# Altium schematic sheet main.SchDoc
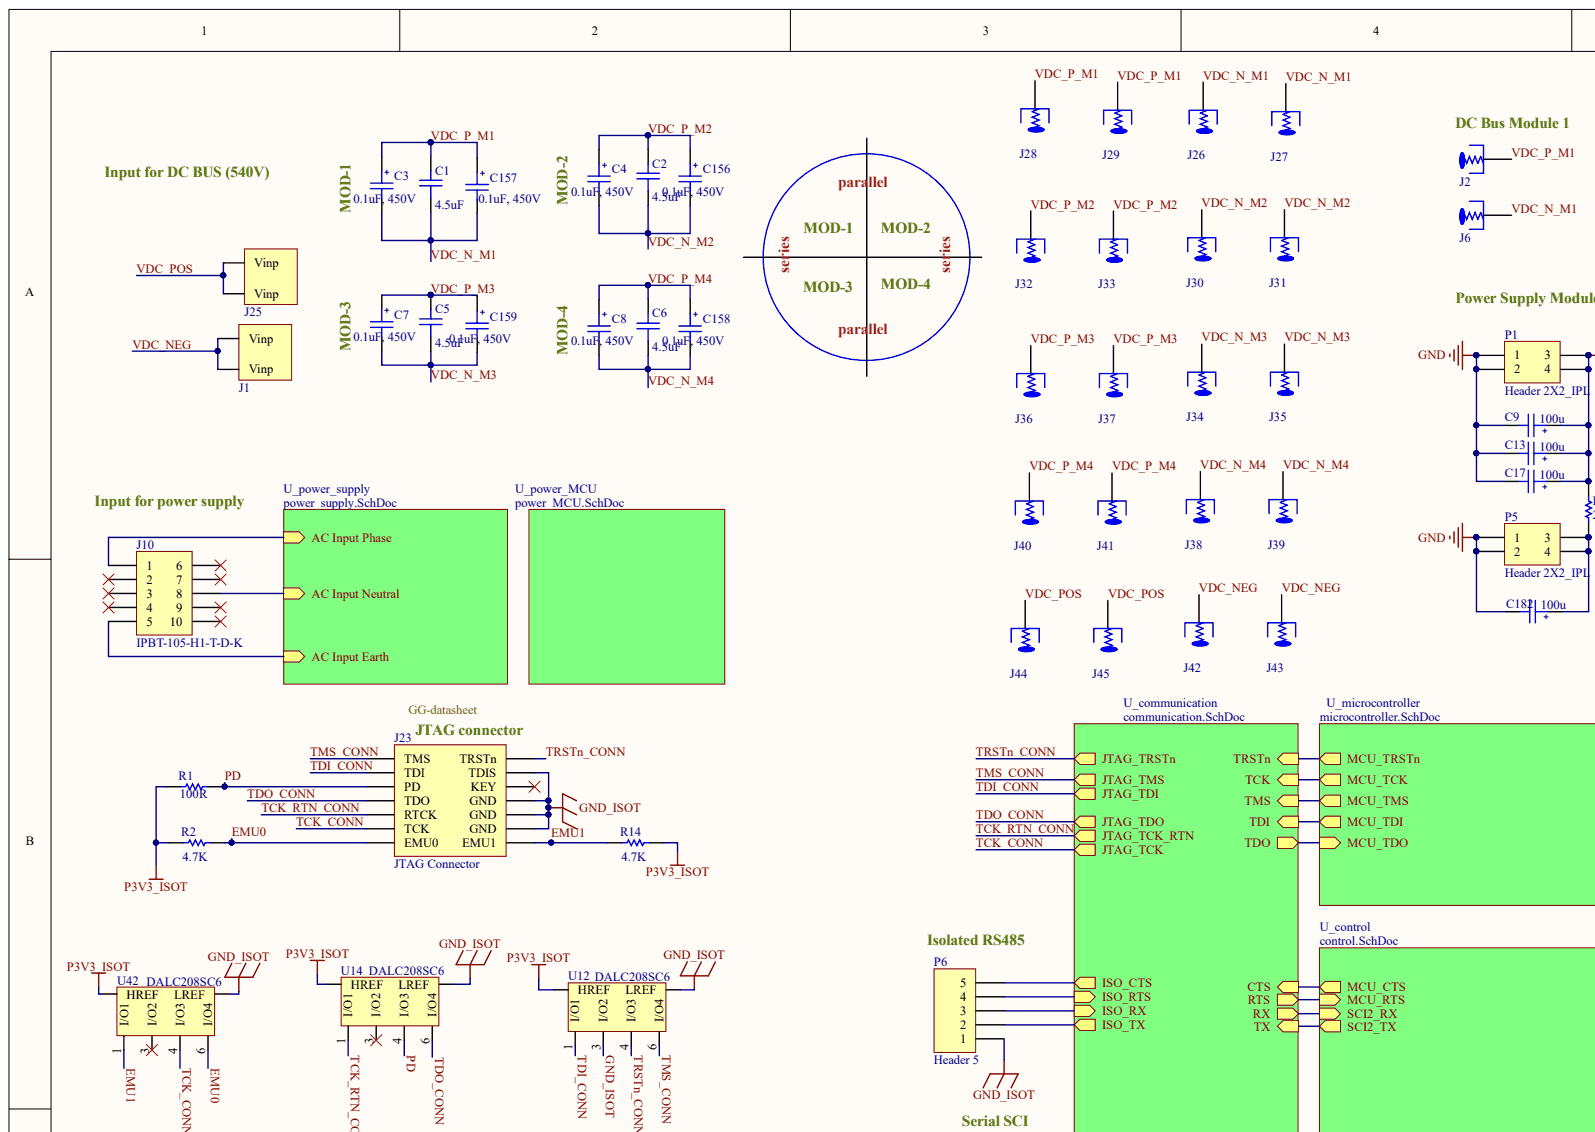
[diagram p0: main.SchDoc, top left]
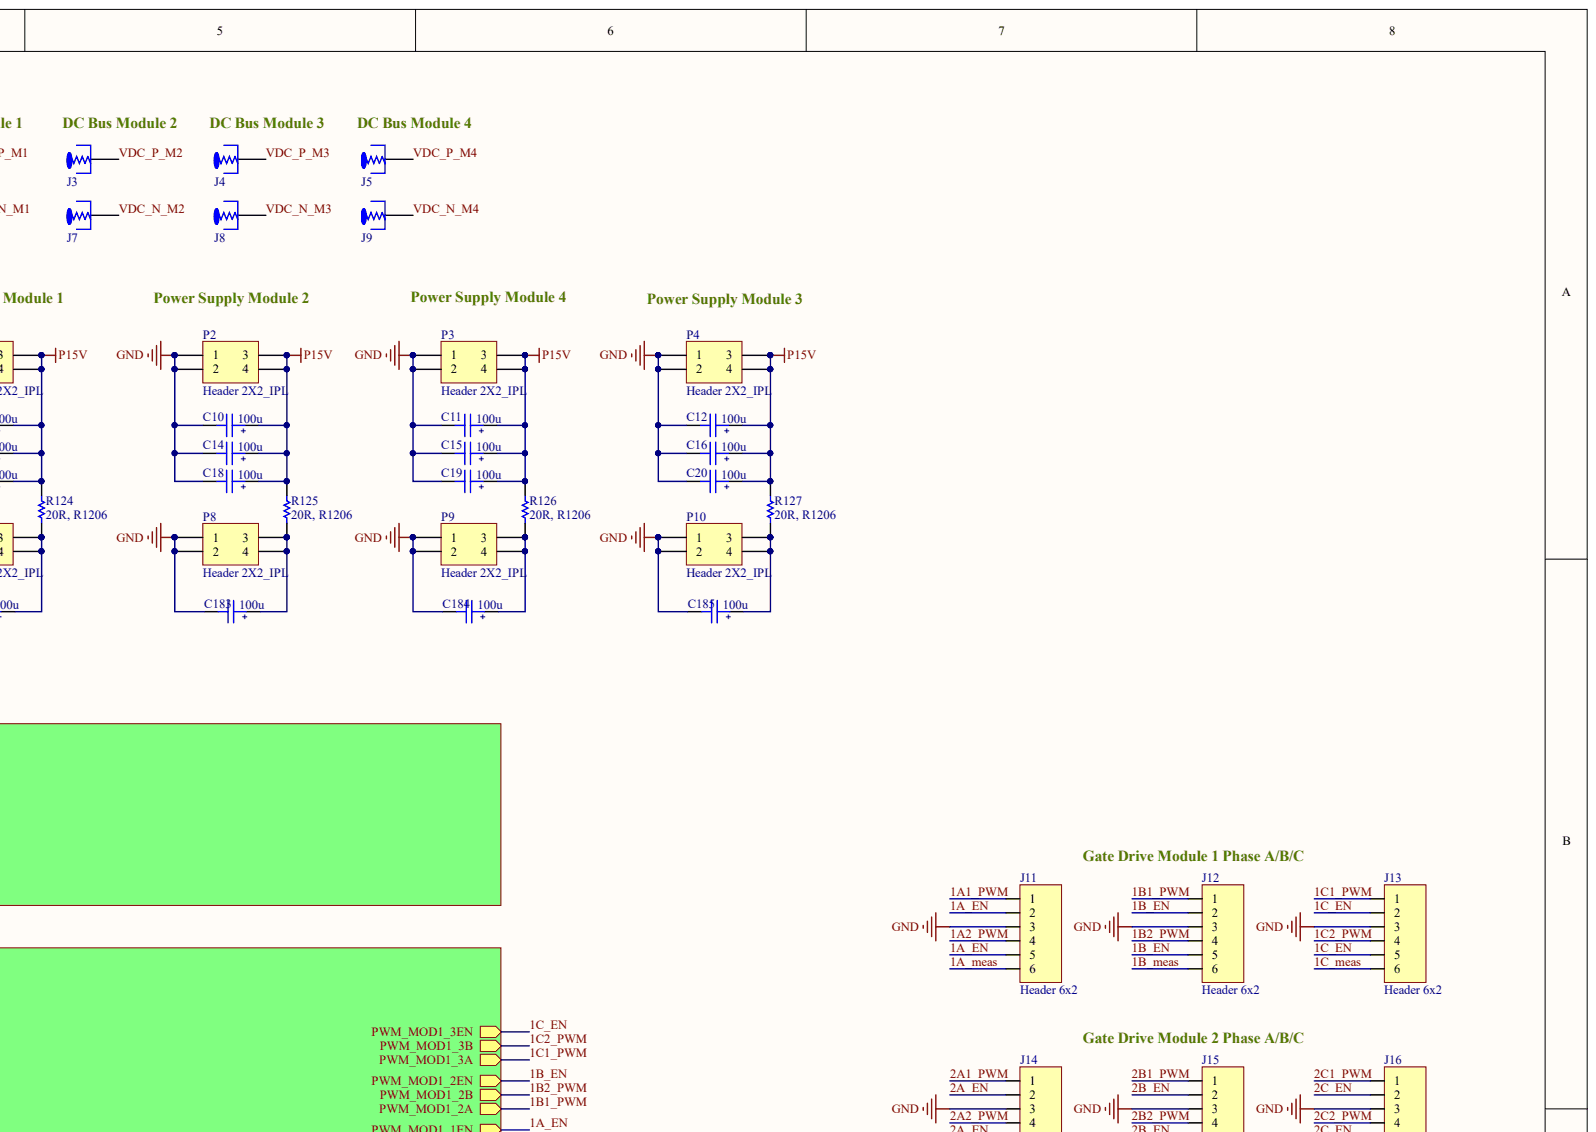
[diagram p1: main.SchDoc, top right]
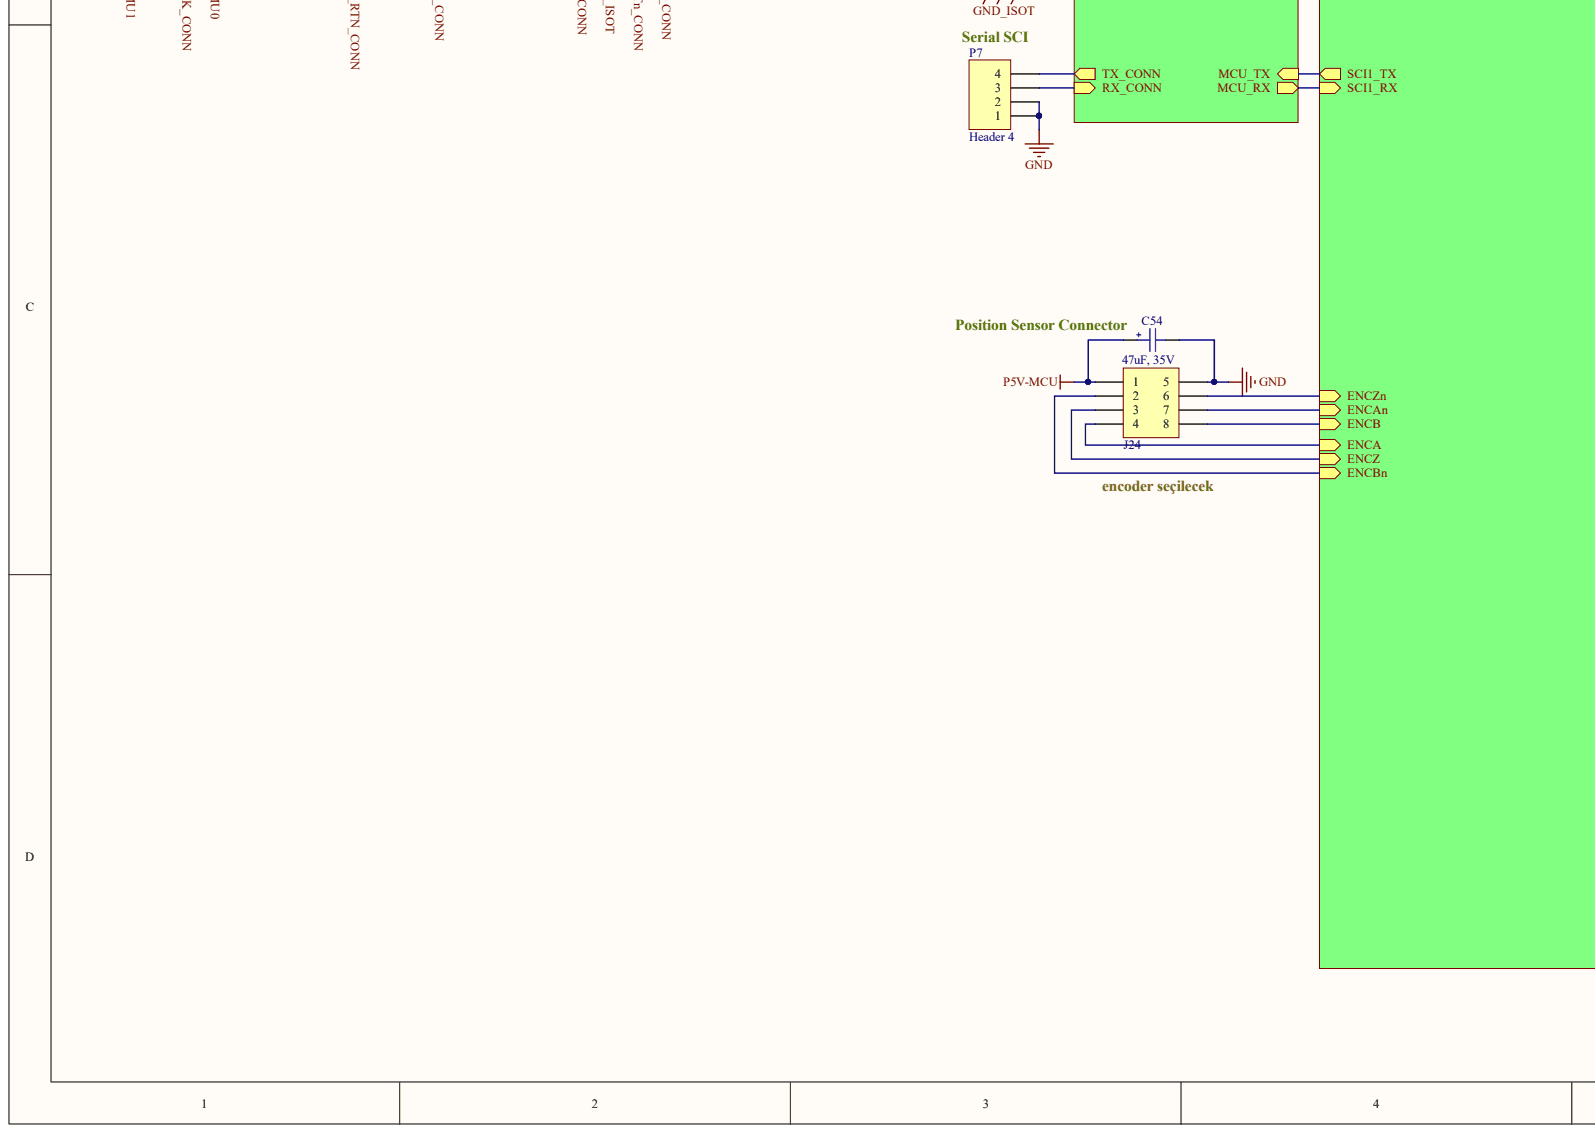
[diagram p2: main.SchDoc, bottom left]
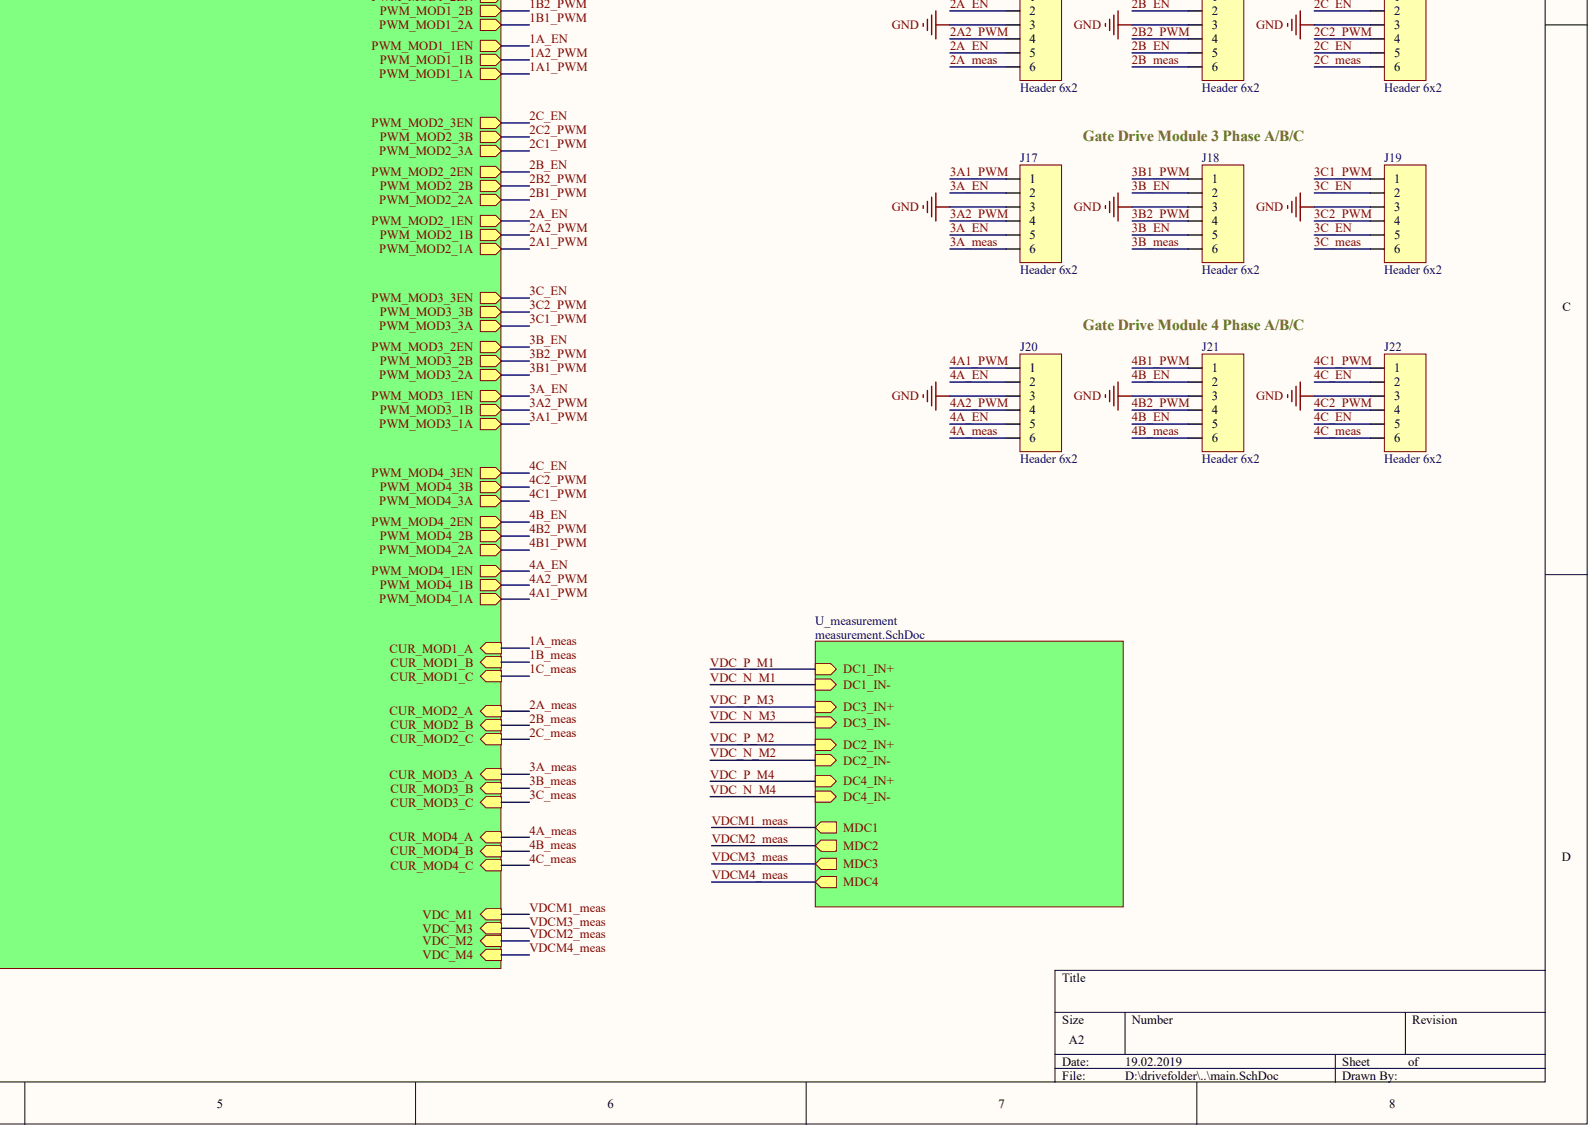
[diagram p3: main.SchDoc, bottom right]

## Parts
C1  4.5uF  [Film_Cap_11*31.5*21]
C2  4.5uF  [Film_Cap_11*31.5*21]
C3  0.1uF, 450V  [1206 Package]
C4  0.1uF, 450V  [1206 Package]
C5  4.5uF  [Film_Cap_11*31.5*21]
C6  4.5uF  [Film_Cap_11*31.5*21]
C7  0.1uF, 450V  [1206 Package]
C8  0.1uF, 450V  [1206 Package]
C9  100u  [CAPPR6.3x]
C10  100u  [CAPPR6.3x]
C11  100u  [CAPPR6.3x]
C12  100u  [CAPPR6.3x]
C13  100u  [CAPPR6.3x]
C14  100u  [CAPPR6.3x]
C15  100u  [CAPPR6.3x]
C16  100u  [CAPPR6.3x]
C17  100u  [CAPPR6.3x]
C18  100u  [CAPPR6.3x]
C19  100u  [CAPPR6.3x]
C20  100u  [CAPPR6.3x]
C54  47uF, 35V  [CAP_CAN_D5]
C156  0.1uF, 450V  [1206 Package]
C157  0.1uF, 450V  [1206 Package]
C158  0.1uF, 450V  [1206 Package]
C159  0.1uF, 450V  [1206 Package]
C182  100u  [CAPPR6.3x]
C183  100u  [CAPPR6.3x]
C184  100u  [CAPPR6.3x]
C185  100u  [CAPPR6.3x]
J1  Terminal_Block_30Deg  [Term_Block_30DEG]  (value not drawn)
J2  Terminal_Screw  [Terminal_Screw]  (value not drawn)
J3  Terminal_Screw  [Terminal_Screw]  (value not drawn)
J4  Terminal_Screw  [Terminal_Screw]  (value not drawn)
J5  Terminal_Screw  [Terminal_Screw]  (value not drawn)
J6  Terminal_Screw  [Terminal_Screw]  (value not drawn)
J7  Terminal_Screw  [Terminal_Screw]  (value not drawn)
J8  Terminal_Screw  [Terminal_Screw]  (value not drawn)
J9  Terminal_Screw  [Terminal_Screw]  (value not drawn)
J10  IPBT-105-H1-T-D-K  [IPBT-105-H1-T-D-K]
J11  Header 6x2  [HDR6x2_IPL]
J12  Header 6x2  [HDR6x2_IPL]
J13  Header 6x2  [HDR6x2_IPL]
J14  Header 6x2  [HDR6x2_IPL]
J15  Header 6x2  [HDR6x2_IPL]
J16  Header 6x2  [HDR6x2_IPL]
J17  Header 6x2  [HDR6x2_IPL]
J18  Header 6x2  [HDR6x2_IPL]
J19  Header 6x2  [HDR6x2_IPL]
J20  Header 6x2  [HDR6x2_IPL]
J21  Header 6x2  [HDR6x2_IPL]
J22  Header 6x2  [HDR6x2_IPL]
J23  JTAG Connector  [5103309-2]
J24  Header 4x2  [HDR4X2_IPL]  (value not drawn)
J25  Terminal_Block_30Deg  [Term_Block_30DEG]  (value not drawn)
J26  BUSH, THR OPENM4, THR 2.5MM  [BUSH, THR OPENM4, THR 2.5MM]  (value not drawn)
J27  BUSH, THR OPENM4, THR 2.5MM  [BUSH, THR OPENM4, THR 2.5MM]  (value not drawn)
J28  BUSH, THR OPENM4, THR 2.5MM  [BUSH, THR OPENM4, THR 2.5MM]  (value not drawn)
J29  BUSH, THR OPENM4, THR 2.5MM  [BUSH, THR OPENM4, THR 2.5MM]  (value not drawn)
J30  BUSH, THR OPENM4, THR 2.5MM  [BUSH, THR OPENM4, THR 2.5MM]  (value not drawn)
J31  BUSH, THR OPENM4, THR 2.5MM  [BUSH, THR OPENM4, THR 2.5MM]  (value not drawn)
J32  BUSH, THR OPENM4, THR 2.5MM  [BUSH, THR OPENM4, THR 2.5MM]  (value not drawn)
J33  BUSH, THR OPENM4, THR 2.5MM  [BUSH, THR OPENM4, THR 2.5MM]  (value not drawn)
J34  BUSH, THR OPENM4, THR 2.5MM  [BUSH, THR OPENM4, THR 2.5MM]  (value not drawn)
J35  BUSH, THR OPENM4, THR 2.5MM  [BUSH, THR OPENM4, THR 2.5MM]  (value not drawn)
J36  BUSH, THR OPENM4, THR 2.5MM  [BUSH, THR OPENM4, THR 2.5MM]  (value not drawn)
J37  BUSH, THR OPENM4, THR 2.5MM  [BUSH, THR OPENM4, THR 2.5MM]  (value not drawn)
J38  BUSH, THR OPENM4, THR 2.5MM  [BUSH, THR OPENM4, THR 2.5MM]  (value not drawn)
J39  BUSH, THR OPENM4, THR 2.5MM  [BUSH, THR OPENM4, THR 2.5MM]  (value not drawn)
J40  BUSH, THR OPENM4, THR 2.5MM  [BUSH, THR OPENM4, THR 2.5MM]  (value not drawn)
J41  BUSH, THR OPENM4, THR 2.5MM  [BUSH, THR OPENM4, THR 2.5MM]  (value not drawn)
J42  BUSH, THR OPENM4, THR 2.5MM  [BUSH, THR OPENM4, THR 2.5MM]  (value not drawn)
J43  BUSH, THR OPENM4, THR 2.5MM  [BUSH, THR OPENM4, THR 2.5MM]  (value not drawn)
J44  BUSH, THR OPENM4, THR 2.5MM  [BUSH, THR OPENM4, THR 2.5MM]  (value not drawn)
J45  BUSH, THR OPENM4, THR 2.5MM  [BUSH, THR OPENM4, THR 2.5MM]  (value not drawn)
P1  Header 2X2_IPL  [HDR2X2_IPL]
P2  Header 2X2_IPL  [HDR2X2_IPL]
P3  Header 2X2_IPL  [HDR2X2_IPL]
P4  Header 2X2_IPL  [HDR2X2_IPL]
P5  Header 2X2_IPL  [HDR2X2_IPL]
P6  Header 5  [HDR1X5]
P7  Header 4  [HDR1X4]
P8  Header 2X2_IPL  [HDR2X2_IPL]
P9  Header 2X2_IPL  [HDR2X2_IPL]
P10  Header 2X2_IPL  [HDR2X2_IPL]
R1  100R  [CAP0603]
R2  4.7K  [0603 (1608)]
R14  4.7K  [0603 (1608)]
R124  20R, R1206  [R1206]
R125  20R, R1206  [R1206]
R126  20R, R1206  [R1206]
R127  20R, R1206  [R1206]
U12  DALC208SC6  [SOT23 - 6 lead]
U14  DALC208SC6  [SOT23 - 6 lead]
U42  DALC208SC6  [SOT23 - 6 lead]

## Nets
GND: C9.2 C10.2 C11.2 C12.2 C13.2 C14.2 C15.2 C16.2 C17.2 C18.2 C19.2 C20.2 C54.2 C182.2 C183.2 C184.2 C185.2 J11.3 J12.3 J13.3 J14.3 J15.3 J16.3 J17.3 J18.3 J19.3 J20.3 J21.3 J22.3 J24.5 P1.1 P1.2 P2.1 P2.2 P3.1 P3.2 P4.1 P4.2 P5.1 P5.2 P7.1 P7.2 P8.1 P8.2 P9.1 P9.2 P10.1 P10.2
P3V3_ISOT: R1.1 R2.1 R14.2 U12.2(HREF) U14.2(HREF) U42.2(HREF)
P5V-MCU: C54.1 J24.1
P15V: C9.1 C10.1 C11.1 C12.1 C13.1 C14.1 C15.1 C16.1 C17.1 C18.1 C19.1 C20.1 P1.3 P1.4 P2.3 P2.4 P3.3 P3.4 P4.3 P4.4 R124.2 R125.2 R126.2 R127.2
1A1_PWM: J11.1
1A2_PWM: J11.4
1A_EN: J11.2 J11.5
1A_MEAS: J11.6
1B1_PWM: J12.1
1B2_PWM: J12.4
1B_EN: J12.2 J12.5
1B_MEAS: J12.6
1C1_PWM: J13.1
1C2_PWM: J13.4
1C_EN: J13.2 J13.5
1C_MEAS: J13.6
2A1_PWM: J14.1
2A2_PWM: J14.4
2A_EN: J14.2 J14.5
2A_MEAS: J14.6
2B1_PWM: J15.1
2B2_PWM: J15.4
2B_EN: J15.2 J15.5
2B_MEAS: J15.6
2C1_PWM: J16.1
2C2_PWM: J16.4
2C_EN: J16.2 J16.5
2C_MEAS: J16.6
3A1_PWM: J17.1
3A2_PWM: J17.4
3A_EN: J17.2 J17.5
3A_MEAS: J17.6
3B1_PWM: J18.1
3B2_PWM: J18.4
3B_EN: J18.2 J18.5
3B_MEAS: J18.6
3C1_PWM: J19.1
3C2_PWM: J19.4
3C_EN: J19.2 J19.5
3C_MEAS: J19.6
4A1_PWM: J20.1
4A2_PWM: J20.4
4A_EN: J20.2 J20.5
4A_MEAS: J20.6
4B1_PWM: J21.1
4B2_PWM: J21.4
4B_EN: J21.2 J21.5
4B_MEAS: J21.6
4C1_PWM: J22.1
4C2_PWM: J22.4
4C_EN: J22.2 J22.5
4C_MEAS: J22.6
EMU0: J23.13(EMU0) R2.2 U42.6(I/O4)
EMU1: J23.14(EMU1) R14.1 U42.1(I/O1)
GND_ISOT: J23.4(TDIS) J23.8(GND) J23.10(GND) J23.12(GND) P6.1 U12.3(I/O2) U12.5(LREF) U14.5(LREF) U42.5(LREF)
PD: J23.5(PD) R1.2 U14.4(I/O3)
TCK_CONN: J23.11(TCK) U42.4(I/O3)
TCK_RTN_CONN: J23.9(RTCK) U14.1(I/O1)
TDI_CONN: J23.3(TDI) U12.1(I/O1)
TDO_CONN: J23.7(TDO) U14.6(I/O4)
TMS_CONN: J23.1(TMS) U12.6(I/O4)
TRSTN_CONN: J23.2(TRSTn) U12.4(I/O3)
VDC_N_M1: C1.1 C3.2 C157.2 J6.1 J26.1 J27.1
VDC_N_M2: C2.1 C4.2 C156.2 J7.1 J30.1 J31.1
VDC_N_M3: C5.1 C7.2 C159.2 J8.1 J34.1 J35.1
VDC_N_M4: C6.1 C8.2 C158.2 J9.1 J38.1 J39.1
VDC_NEG: J1.1 J42.1 J43.1
VDC_P_M1: C1.2 C3.1 C157.1 J2.1 J28.1 J29.1
VDC_P_M2: C2.2 C4.1 C156.1 J3.1 J32.1 J33.1
VDC_P_M3: C5.2 C7.1 C159.1 J4.1 J36.1 J37.1
VDC_P_M4: C6.2 C8.1 C158.1 J5.1 J40.1 J41.1
VDC_POS: J25.1 J44.1 J45.1
NetC182_1: C182.1 P5.3 P5.4 R124.1
NetC183_1: C183.1 P8.3 P8.4 R125.1
NetC184_1: C184.1 P9.3 P9.4 R126.1
NetC185_1: C185.1 P10.3 P10.4 R127.1
NetJ10_1: J10.1
NetJ10_2: J10.2
NetJ10_3: J10.3
NetJ10_4: J10.4
NetJ10_5: J10.5
NetJ10_6: J10.6
NetJ10_7: J10.7
NetJ10_8: J10.8
NetJ10_9: J10.9
NetJ10_10: J10.10
NetJ23_6: J23.6(KEY)
NetJ24_2: J24.2
NetJ24_3: J24.3
NetJ24_4: J24.4
NetJ24_6: J24.6
NetJ24_7: J24.7
NetJ24_8: J24.8
NetP6_2: P6.2
NetP6_3: P6.3
NetP6_4: P6.4
NetP6_5: P6.5
NetP7_3: P7.3
NetP7_4: P7.4
NetU14_3: U14.3(I/O2)
NetU42_3: U42.3(I/O2)
CTS:
MCU_RX:
MCU_TX:
RTS:
RX:
TCK:
TDI:
TDO:
TMS:
TRSTN:
TX:
VDCM1_MEAS:
VDCM2_MEAS:
VDCM3_MEAS:
VDCM4_MEAS:

## Library data of the parts
C1 C2 C5 C6 = Film Capacitor2: C4AEGBU4450A1WJ; Component Kind: Standard; ComponentLink1Description: Digikey; ComponentLink1URL: https://www.digikey.com/product-detail/en/kemet/C4AEGBU4450A1WJ/399-13072-ND/5803734; Library Name: immd_schlib.SchLib
C3 C4 C7 C8 C156-C159 = CAP1206 - Polar: ATPH33MAHA; Component Kind: Standard; ComponentLink1Description: Digikey; ComponentLink1URL: https://www.digikey.com/product-detail/en/tdk-corporation/C3216X7T2W104K160AE/445-8897-1-ND/3283701; Library Name: pasif.SchLib
C9-C20 C182-C185 = Cap4 (6.3x): CAP ALUM 100UF 20% 25V SMD; Component Kind: Standard; ComponentLink1Description: Digikey; ComponentLink1URL: https://www.digikey.com/product-detail/en/kemet/EDK107M025A9HAA/399-6670-1-ND/3082802; Library Name: pasif.SchLib
C54 = CAP_CAN: CAP ALUM 47UF 20% 35V SMD; Component Kind: Standard; ComponentLink1Description: Digikey; ComponentLink1URL: https://www.digikey.com/products/en/capacitors/aluminum-electrolytic-capacitors/58?k=EEE-FK1V470P&k=&pkeyword=EEE-FK1V470P&pv7=2&sf=0&quantity=&ColumnSort=0&page=1&pageSize=25; Library Name: pasif.SchLib
J1 J25 = Terminal_Block_30Deg: CONN TERM BLOCK 1POS 30DEG; Component Kind: Standard; ComponentLink1Description: Digikey; ComponentLink1URL: https://www.digikey.com/product-detail/en/phoenix-contact/1792216/277-9885-ND/4357163; Library Name: immd_schlib.SchLib
J2-J9 = Terminal_Screw: TERM SCREW M4 4 PIN PCB RA; Component Kind: Standard; ComponentLink1Description: Digikey; ComponentLink1URL: https://www.digikey.com/product-detail/en/keystone-electronics/7764/36-7764-ND/4499344; Library Name: immd_schlib.SchLib
J10 = IPBT-105-H1-T-D-K: Connector Header Through Hole 10 position 0.165" (4.20mm); Component Kind: Standard; ComponentLink1Description: Digikey(Tube); ComponentLink1URL: https://www.digikey.com/products/en?keywords=IPBT-105-H1-T-D-K; Library Name: konnektor.SchLib
J23 = Header 7x2E: JTAG Connector 7X2; Component Kind: Standard; Library Name: konnektor.SchLib
J24 = Header 4X2_IPL: Component Kind: Standard; Library Name: konnektor.SchLib
P6 = Header 5: Header, 5-Pin; Component Kind: Standard; LatestRevisionDate: 17-Jul-2002; LatestRevisionNote: Re-released for DXP Platform.; Library Name: Miscellaneous Connectors.IntLib; Signal Integrity: Connector; Publisher: Altium Limited
P7 = Header 4: Header, 4-Pin; Component Kind: Standard; LatestRevisionDate: 17-Jul-2002; LatestRevisionNote: Re-released for DXP Platform.; Library Name: Miscellaneous Connectors.IntLib; Signal Integrity: Connector; Publisher: Altium Limited
R1 = Res6 (0603): ERJ-3EKF1000V; Component Kind: Standard; ComponentLink1Description: Digikey; ComponentLink1URL: https://www.digikey.com/product-detail/en/panasonic-electronic-components/ERJ-3EKF1000V/P100HCT-ND/198109
R2 R14 = R0603: RC0603FR-072K2L; Component Kind: Standard; ComponentLink1Description: Digikey; ComponentLink1URL: https://www.digikey.com/product-detail/en/yageo/RC0603JR-074K7L/311-4.7KGRCT-ND/729732; Library Name: immd_schlib.SchLib
R124-R127 = Res7 (1206): RES SMD 20 OHM 5% 2/3W 1206; Component Kind: Standard; ComponentLink1Description: Digikey; ComponentLink1URL: https://www.digikey.com/product-detail/en/panasonic-electronic-components/ERJ-P08J200V/P20ALCT-ND/525591; ComponentLink2Description: Digikey PN; ComponentLink2URL: P20ALCT-ND; Library Name: pasif.SchLib
U12 U14 U42 = DALC208: TVS DIODE 5V SOT23-6; Component Kind: Standard; ComponentLink1Description: Digikey; ComponentLink1URL: https://www.digikey.com/product-detail/en/stmicroelectronics/DALC208SC6/497-2525-1-ND/603907; Library Name: immd_schlib.SchLib
J11-J22 = Header 6x2: Component Kind: Standard; Library Name: konnektor.SchLib
J26-J45 = BUSH, THR OPENM4, THR 2.5MM: Component Kind: Standard; ComponentLink1Description: Digikey; ComponentLink1URL: https://www.digikey.com/product-detail/en/wurth-electronics-inc/74650074R/732-10883-2-ND/6643979; Description: BUSH, THR OPENM4, THR 2.5MM; Library Name: immd_schlib.SchLib
P1-P5 P8-P10 = Header 2X2_IPL: Component Kind: Standard; Library Name: konnektor.SchLib
